# Altium schematic sheet Sensor.SchDoc
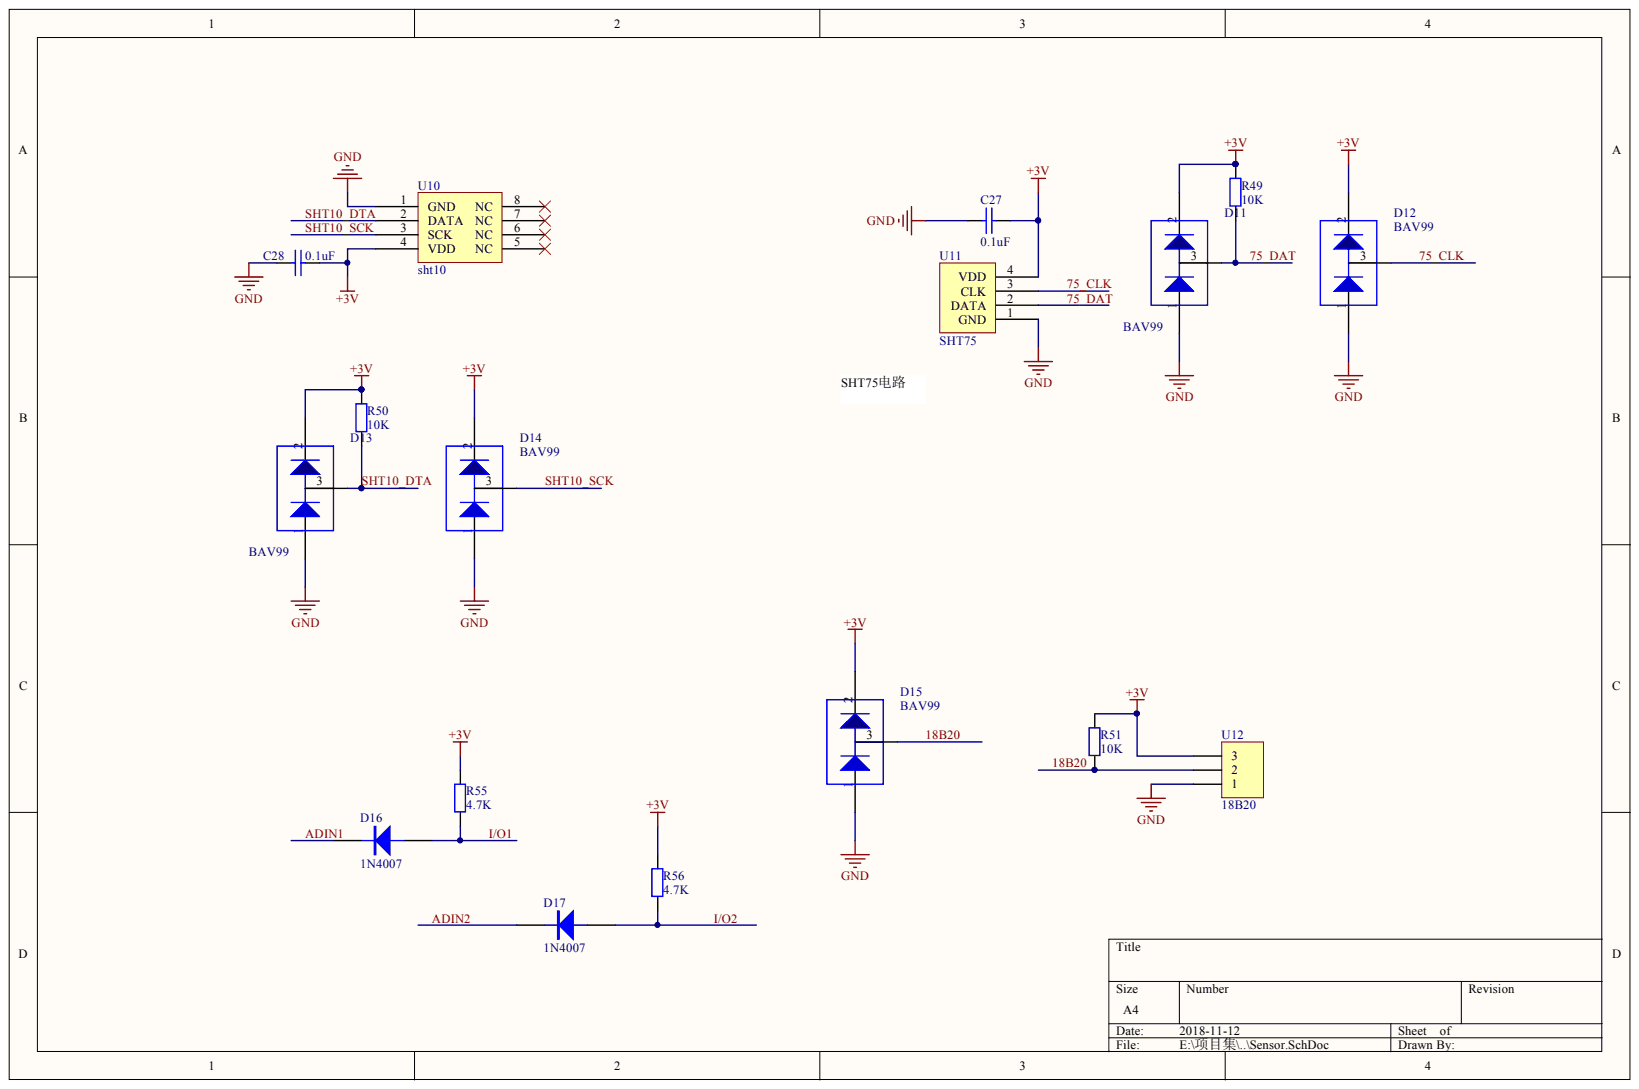
[diagram p0: Sensor.SchDoc]

## Parts
C27  0.1uF  [0603C]
C28  0.1uF  [0603C]
D11  BAV99  [SOT-23]
D12  BAV99  [SOT-23]
D13  BAV99  [SOT-23]
D14  BAV99  [SOT-23]
D15  BAV99  [SOT-23]
D16  1N4007  [SMA]
D17  1N4007  [SMA]
R49  10K  [0603R]
R50  10K  [0603R]
R51  10K  [0603R]
R55  4.7K  [0603R]
R56  4.7K  [0603R]
U10  sht10  [SHT10]
U11  SHT75  [XH4]
U12  18B20  [XH3]

## Nets
+3V: C27.2 C28.2 D11.2 D12.2 D13.2 D14.2 D15.2 R49.2 R50.2 R51.2 R55.2 R56.2 U10.4(VDD) U11.4(VDD) U12.3
GND: C27.1 C28.1 D11.1 D12.1 D13.1 D14.1 D15.1 U10.1(GND) U11.1(GND) U12.1
18B20: D15.3 R51.1 U12.2
75_CLK: D12.3 U11.3(CLK)
75_DAT: D11.3 R49.1 U11.2(DATA)
ADIN1: D16.2
ADIN2: D17.2
I/O1: D16.1 R55.1
I/O2: D17.1 R56.1
SHT10_DTA: D13.3 R50.1 U10.2(DATA)
SHT10_SCK: D14.3 U10.3(SCK)

Not connected: U10 5(NC) 6(NC) 7(NC) 8(NC)

## Library data of the parts
C27 C28 = CAP: Component Kind: Standard; Library Name: My Devices.SCHLIB
D11-D15 = D-DIODE: Component Kind: Standard; Library Name: My Devices.SCHLIB
D16 D17 = DIODE: Component Kind: Standard; Library Name: My Devices.SCHLIB
R49-R51 R55 R56 = RES2: Component Kind: Standard; Library Name: My Devices.SCHLIB
U12 = Header 3: Header, 3-Pin; Component Kind: Standard; LatestRevisionDate: 17-Jul-2002; LatestRevisionNote: Re-released for DXP Platform.; Library Name: Miscellaneous Connectors.IntLib; Publisher: Altium Limited
U10 = sht10: Component Kind: Standard; Library Name: My Devices.SCHLIB
U11 = SHT75: Component Kind: Standard; Library Name: My Devices.SCHLIB
